# Altium schematic sheet DE-140824_01.SchDoc(DE-140824_01)
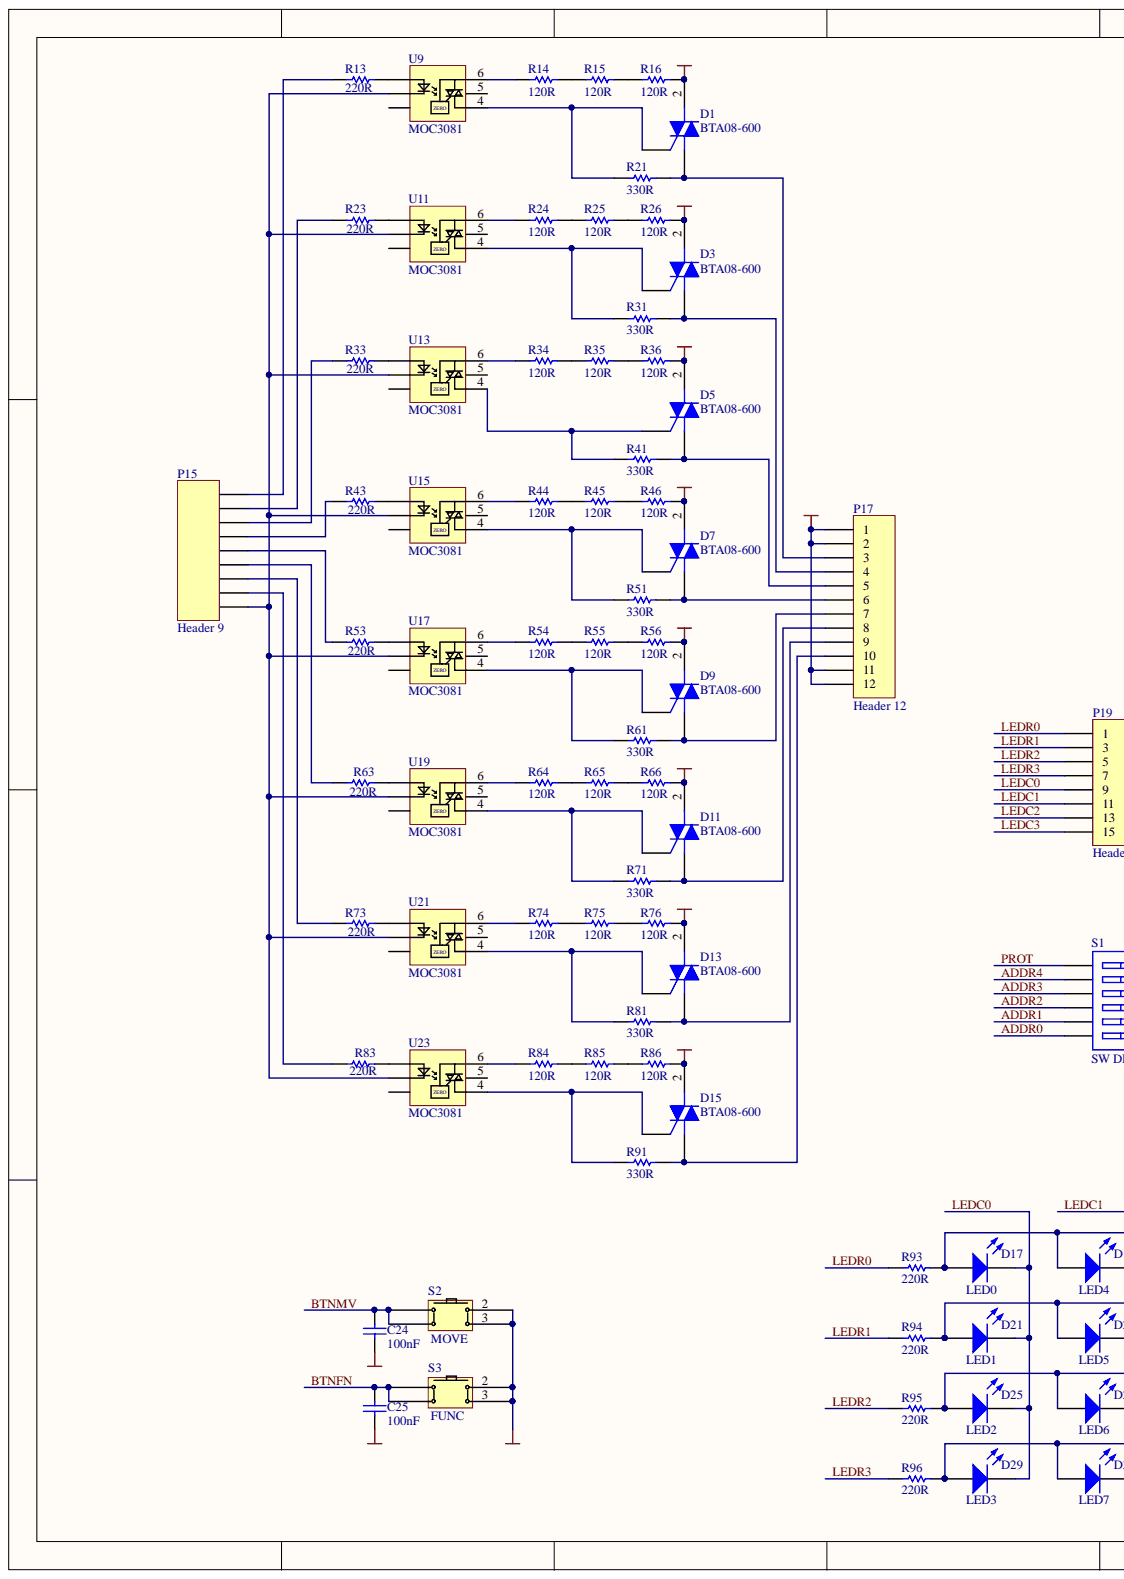
[diagram p0: DE-140824_01.SchDoc, left]
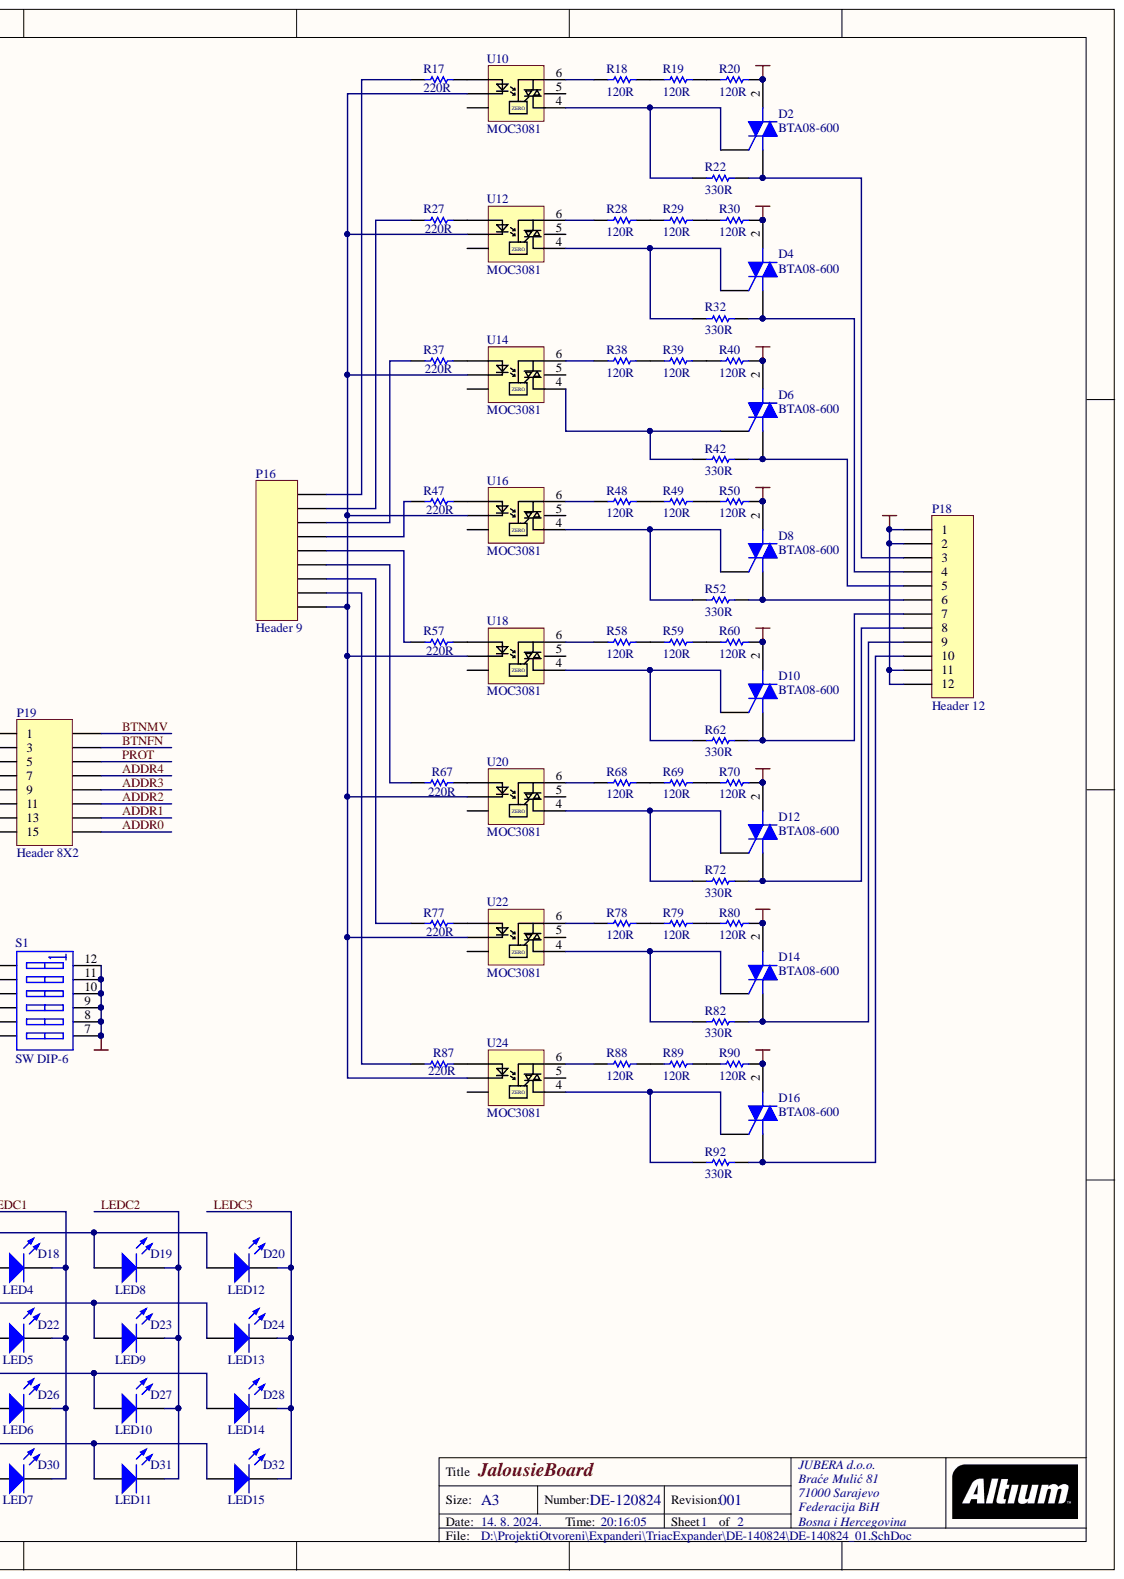
[diagram p1: DE-140824_01.SchDoc, right]

## Parts
C24  value 100nF  [0603]  (hidden comment: C0603)
C25  value 100nF  [0603]  (hidden comment: C0603)
D1  BTA08-600  [ONSC-TO-220-3-221A-07]
D2  BTA08-600  [ONSC-TO-220-3-221A-07]
D3  BTA08-600  [ONSC-TO-220-3-221A-07]
D4  BTA08-600  [ONSC-TO-220-3-221A-07]
D5  BTA08-600  [ONSC-TO-220-3-221A-07]
D6  BTA08-600  [ONSC-TO-220-3-221A-07]
D7  BTA08-600  [ONSC-TO-220-3-221A-07]
D8  BTA08-600  [ONSC-TO-220-3-221A-07]
D9  BTA08-600  [ONSC-TO-220-3-221A-07]
D10  BTA08-600  [ONSC-TO-220-3-221A-07]
D11  BTA08-600  [ONSC-TO-220-3-221A-07]
D12  BTA08-600  [ONSC-TO-220-3-221A-07]
D13  BTA08-600  [ONSC-TO-220-3-221A-07]
D14  BTA08-600  [ONSC-TO-220-3-221A-07]
D15  BTA08-600  [ONSC-TO-220-3-221A-07]
D16  BTA08-600  [ONSC-TO-220-3-221A-07]
D17  LED0  [1.6X0.8X0.8]
D18  LED4  [1.6X0.8X0.8]
D19  LED8  [1.6X0.8X0.8]
D20  LED12  [1.6X0.8X0.8]
D21  LED1  [1.6X0.8X0.8]
D22  LED5  [1.6X0.8X0.8]
D23  LED9  [1.6X0.8X0.8]
D24  LED13  [1.6X0.8X0.8]
D25  LED2  [1.6X0.8X0.8]
D26  LED6  [1.6X0.8X0.8]
D27  LED10  [1.6X0.8X0.8]
D28  LED14  [1.6X0.8X0.8]
D29  LED3  [1.6X0.8X0.8]
D30  LED7  [1.6X0.8X0.8]
D31  LED11  [1.6X0.8X0.8]
D32  LED15  [1.6X0.8X0.8]
P15  Header 9  [HDR1X9]
P16  Header 9  [HDR1X9]
P17  Header 12  [HDR1X12]
P18  Header 12  [HDR1X12]
P19  Header 8X2  [HDR2X8]
R13  220R  [ERA-0603]
R14  120R  [ERA-0805]
R15  120R  [ERA-0805]
R16  120R  [ERA-0805]
R17  220R  [ERA-0603]
R18  120R  [ERA-0805]
R19  120R  [ERA-0805]
R20  120R  [ERA-0805]
R21  330R  [ERA-0805]
R22  330R  [ERA-0805]
R23  220R  [ERA-0603]
R24  120R  [ERA-0805]
R25  120R  [ERA-0805]
R26  120R  [ERA-0805]
R27  220R  [ERA-0603]
R28  120R  [ERA-0805]
R29  120R  [ERA-0805]
R30  120R  [ERA-0805]
R31  330R  [ERA-0805]
R32  330R  [ERA-0805]
R33  220R  [ERA-0603]
R34  120R  [ERA-0805]
R35  120R  [ERA-0805]
R36  120R  [ERA-0805]
R37  220R  [ERA-0603]
R38  120R  [ERA-0805]
R39  120R  [ERA-0805]
R40  120R  [ERA-0805]
R41  330R  [ERA-0805]
R42  330R  [ERA-0805]
R43  220R  [ERA-0603]
R44  120R  [ERA-0805]
R45  120R  [ERA-0805]
R46  120R  [ERA-0805]
R47  220R  [ERA-0603]
R48  120R  [ERA-0805]
R49  120R  [ERA-0805]
R50  120R  [ERA-0805]
R51  330R  [ERA-0805]
R52  330R  [ERA-0805]
R53  220R  [ERA-0603]
R54  120R  [ERA-0805]
R55  120R  [ERA-0805]
R56  120R  [ERA-0805]
R57  220R  [ERA-0603]
R58  120R  [ERA-0805]
R59  120R  [ERA-0805]
R60  120R  [ERA-0805]
R61  330R  [ERA-0805]
R62  330R  [ERA-0805]
R63  220R  [ERA-0603]
R64  120R  [ERA-0805]
R65  120R  [ERA-0805]
R66  120R  [ERA-0805]
R67  220R  [ERA-0603]
R68  120R  [ERA-0805]
R69  120R  [ERA-0805]
R70  120R  [ERA-0805]
R71  330R  [ERA-0805]
R72  330R  [ERA-0805]
R73  220R  [ERA-0603]
R74  120R  [ERA-0805]
R75  120R  [ERA-0805]
R76  120R  [ERA-0805]
R77  220R  [ERA-0603]
R78  120R  [ERA-0805]
R79  120R  [ERA-0805]
R80  120R  [ERA-0805]
R81  330R  [ERA-0805]
R82  330R  [ERA-0805]
R83  220R  [ERA-0603]
R84  120R  [ERA-0805]
R85  120R  [ERA-0805]
R86  120R  [ERA-0805]
R87  220R  [ERA-0603]
R88  120R  [ERA-0805]
R89  120R  [ERA-0805]
R90  120R  [ERA-0805]
R91  330R  [ERA-0805]
R92  330R  [ERA-0805]
R93  220R  [ERA-0603]
R94  220R  [ERA-0603]
R95  220R  [ERA-0603]
R96  220R  [ERA-0603]
S1  SW DIP-6  [DIP-12/SW]
S2  MOVE  [TACTILE_SWITCH]
S3  FUNC  [TACTILE_SWITCH]
U9  MOC3081  [DIP6]
U10  MOC3081  [DIP6]
U11  MOC3081  [DIP6]
U12  MOC3081  [DIP6]
U13  MOC3081  [DIP6]
U14  MOC3081  [DIP6]
U15  MOC3081  [DIP6]
U16  MOC3081  [DIP6]
U17  MOC3081  [DIP6]
U18  MOC3081  [DIP6]
U19  MOC3081  [DIP6]
U20  MOC3081  [DIP6]
U21  MOC3081  [DIP6]
U22  MOC3081  [DIP6]
U23  MOC3081  [DIP6]
U24  MOC3081  [DIP6]

## Nets
F1: D1.2 D3.2 D5.2 D7.2 D9.2 D11.2 D13.2 D15.2 P17.1 P17.2 P17.11 P17.12 R16.2 R26.2 R36.2 R46.2 R56.2 R66.2 R76.2 R86.2
F2: D2.2 D4.2 D6.2 D8.2 D10.2 D12.2 D14.2 D16.2 P18.1 P18.2 P18.11 P18.12 R20.2 R30.2 R40.2 R50.2 R60.2 R70.2 R80.2 R90.2
GND1: C24.2 C25.2 S1.7 S1.8 S1.9 S1.10 S1.11 S1.12 S2.2 S2.3 S3.2 S3.3
ADDR0: P19.16 S1.6
ADDR1: P19.14 S1.5
ADDR2: P19.12 S1.4
ADDR3: P19.10 S1.3
ADDR4: P19.8 S1.2
BTNFN: C25.1 P19.4 S3.1 S3.4
BTNMV: C24.1 P19.2 S2.1 S2.4
LEDC0: D17.2 D21.2 D25.2 D29.2 P19.9
LEDC1: D18.2 D22.2 D26.2 D30.2 P19.11
LEDC2: D19.2 D23.2 D27.2 D31.2 P19.13
LEDC3: D20.2 D24.2 D28.2 D32.2 P19.15
LEDR0: P19.1 R93.1
LEDR1: P19.3 R94.1
LEDR2: P19.5 R95.1
LEDR3: P19.7 R96.1
PROT: P19.6 S1.1
NetD1_1: D1.1 P17.3 R21.2
NetD1_3: D1.3 R21.1 U9.4
NetD2_1: D2.1 P18.3 R22.2
NetD2_3: D2.3 R22.1 U10.4
NetD3_1: D3.1 P17.4 R31.2
NetD3_3: D3.3 R31.1 U11.4
NetD4_1: D4.1 P18.4 R32.2
NetD4_3: D4.3 R32.1 U12.4
NetD5_1: D5.1 P17.5 R41.2
NetD5_3: D5.3 R41.1 U13.4
NetD6_1: D6.1 P18.5 R42.2
NetD6_3: D6.3 R42.1 U14.4
NetD7_1: D7.1 P17.6 R51.2
NetD7_3: D7.3 R51.1 U15.4
NetD8_1: D8.1 P18.6 R52.2
NetD8_3: D8.3 R52.1 U16.4
NetD9_1: D9.1 P17.7 R61.2
NetD9_3: D9.3 R61.1 U17.4
NetD10_1: D10.1 P18.7 R62.2
NetD10_3: D10.3 R62.1 U18.4
NetD11_1: D11.1 P17.8 R71.2
NetD11_3: D11.3 R71.1 U19.4
NetD12_1: D12.1 P18.8 R72.2
NetD12_3: D12.3 R72.1 U20.4
NetD13_1: D13.1 P17.9 R81.2
NetD13_3: D13.3 R81.1 U21.4
NetD14_1: D14.1 P18.9 R82.2
NetD14_3: D14.3 R82.1 U22.4
NetD15_1: D15.1 P17.10 R91.2
NetD15_3: D15.3 R91.1 U23.4
NetD16_1: D16.1 P18.10 R92.2
NetD16_3: D16.3 R92.1 U24.4
NetD17_1: D17.1 D18.1 D19.1 D20.1 R93.2
NetD21_1: D21.1 D22.1 D23.1 D24.1 R94.2
NetD25_1: D25.1 D26.1 D27.1 D28.1 R95.2
NetD29_1: D29.1 D30.1 D31.1 D32.1 R96.2
NetP15_1: P15.1 R13.1
NetP15_2: P15.2 R23.1
NetP15_3: P15.3 R33.1
NetP15_4: P15.4 R43.1
NetP15_5: P15.5 R53.1
NetP15_6: P15.6 R63.1
NetP15_7: P15.7 R73.1
NetP15_8: P15.8 R83.1
NetP15_9: P15.9 U9.2 U11.2 U13.2 U15.2 U17.2 U19.2 U21.2 U23.2
NetP16_1: P16.1 R17.1
NetP16_2: P16.2 R27.1
NetP16_3: P16.3 R37.1
NetP16_4: P16.4 R47.1
NetP16_5: P16.5 R57.1
NetP16_6: P16.6 R67.1
NetP16_7: P16.7 R77.1
NetP16_8: P16.8 R87.1
NetP16_9: P16.9 U10.2 U12.2 U14.2 U16.2 U18.2 U20.2 U22.2 U24.2
NetR13_2: R13.2 U9.1
NetR14_1: R14.1 U9.6
NetR14_2: R14.2 R15.1
NetR15_2: R15.2 R16.1
NetR17_2: R17.2 U10.1
NetR18_1: R18.1 U10.6
NetR18_2: R18.2 R19.1
NetR19_2: R19.2 R20.1
NetR23_2: R23.2 U11.1
NetR24_1: R24.1 U11.6
NetR24_2: R24.2 R25.1
NetR25_2: R25.2 R26.1
NetR27_2: R27.2 U12.1
NetR28_1: R28.1 U12.6
NetR28_2: R28.2 R29.1
NetR29_2: R29.2 R30.1
NetR33_2: R33.2 U13.1
NetR34_1: R34.1 U13.6
NetR34_2: R34.2 R35.1
NetR35_2: R35.2 R36.1
NetR37_2: R37.2 U14.1
NetR38_1: R38.1 U14.6
NetR38_2: R38.2 R39.1
NetR39_2: R39.2 R40.1
NetR43_2: R43.2 U15.1
NetR44_1: R44.1 U15.6
NetR44_2: R44.2 R45.1
NetR45_2: R45.2 R46.1
NetR47_2: R47.2 U16.1
NetR48_1: R48.1 U16.6
NetR48_2: R48.2 R49.1
NetR49_2: R49.2 R50.1
NetR53_2: R53.2 U17.1
NetR54_1: R54.1 U17.6
NetR54_2: R54.2 R55.1
NetR55_2: R55.2 R56.1
NetR57_2: R57.2 U18.1
NetR58_1: R58.1 U18.6
NetR58_2: R58.2 R59.1
NetR59_2: R59.2 R60.1
NetR63_2: R63.2 U19.1
NetR64_1: R64.1 U19.6
NetR64_2: R64.2 R65.1
NetR65_2: R65.2 R66.1
NetR67_2: R67.2 U20.1
NetR68_1: R68.1 U20.6
NetR68_2: R68.2 R69.1
NetR69_2: R69.2 R70.1
NetR73_2: R73.2 U21.1
NetR74_1: R74.1 U21.6
NetR74_2: R74.2 R75.1
NetR75_2: R75.2 R76.1
NetR77_2: R77.2 U22.1
NetR78_1: R78.1 U22.6
NetR78_2: R78.2 R79.1
NetR79_2: R79.2 R80.1
NetR83_2: R83.2 U23.1
NetR84_1: R84.1 U23.6
NetR84_2: R84.2 R85.1
NetR85_2: R85.2 R86.1
NetR87_2: R87.2 U24.1
NetR88_1: R88.1 U24.6
NetR88_2: R88.2 R89.1
NetR89_2: R89.2 R90.1

Not connected: U9 3 5; U10 3 5; U11 3 5; U12 3 5; U13 3 5; U14 3 5; U15 3 5; U16 3 5; U17 3 5; U18 3 5; U19 3 5; U20 3 5; U21 3 5; U22 3 5; U23 3 5; U24 3 5

## Library data of the parts
C24 C25 = C0603: Ceramic Chip Capacitor - Standard; Note: Footprint corresponds to dimensions for bulk cassette packaging. This case size has tightened tolerances.; Simulation: CAP; Signal Integrity: C0603; Published: 17-Jul-2002; PackageReference: 0603; PackageDocument: Mar-2001; Library Name: DE-120824.SchLib; LatestRevisionNote: Silkscreen size reduced.; LatestRevisionDate: 19-Mar-2004; DatasheetDocument: Mar-2001; ComponentLink2URL: http://www.kemet.com/kemet/web/homepage/kechome.nsf/vapubfilesname/F3102TA.pdf/$file/F3102TA.pdf; ComponentLink2Description: Datasheet; ComponentLink1URL: http://www.kemet.com/; ComponentLink1Description: Manufacturer Link; Component Kind: Standard; Code_JEITA: 0603; Code_IPC: 1608 [0603]; Publisher: Altium Limited
D1-D16 = BTA08-600BW3G: Triacs, Silicon Bidirectional Thyristor, 3-Pin TO-220, Pb-Free, Tube; Manufacturer: On Semiconductor; ITSM Max (A): 90; IT(RMS) Max (A): 8; IGT Max (mA): 50; Supplier Part Number 3: 863-BTA08-600BW3G; Supplier Part Number 2: 13P2072; Supplier Part Number 1: BTA08-600BW3G-ND; Supplier 3: Mouser; Supplier 2: Newark; Supplier 1: Digi-Key; RoHS: Pb-Free; PartNumber: BTA08-600BW3G; Packing: Tube; PackageVersion: Rev. O, 07/2008; PackageReference: TO-220-3-221A-07; PackageDescription: 3-Pin Flange Mount, Vertical Straight Lead, Body 9.97 x 4.445 mm, Pitch 2.54 mm; Mounting Technology: Through Hole; Library Name: DE-120824.SchLib; DatasheetVersion: Rev. 1; ComponentLink3URL: http://www.onsemi.com/pub_link/Collateral/221A-07.PDF; ComponentLink3Description: Package Specification; ComponentLink2URL: http://www.onsemi.com/pub_link/Collateral/BTA08-600BW3-D.PDF; ComponentLink2Description: Datasheet; ComponentLink1URL: http://www.onsemi.com/; ComponentLink1Description: Manufacturer URL; Component Kind: Standard
D17-D32 = HSMB-C190: Blue Surface Mount Chip LED, Blue; PCB_Layout: Complies with manufacturer's recommendation.; LuminousIntensity: 1.6mcd (Minimum).; Simulation: Agl_HSMBC110; Signal Integrity: LED; Published: 11-Dec-2002; PackageVersion: Mar-2002; PackageReference: 1.6X0.8X0.8; PackageDescription: SM LED; 2 Flat Leads; Library Name: DE-120824.SchLib; LED_Colour: Blue; DatasheetVersion: 26-Feb-2002; ComponentLink2URL: http://cp.literature.agilent.com/litweb/pdf/5988-5211EN.pdf; ComponentLink2Description: Datasheet; ComponentLink1URL: http://www.avagotech.com/; ComponentLink1Description: Manufacturer Link; Component Kind: Standard; Publisher: Altium Limited
P15 P16 = Header 9: Header, 9-Pin; Signal Integrity: Connector; Library Name: Miscellaneous Connectors.IntLib; LatestRevisionNote: Re-released for DXP Platform.; LatestRevisionDate: 17-Jul-2002; Component Kind: Standard; Publisher: Altium Limited
P17 P18 = Header 12: Header, 12-Pin; Signal Integrity: Connector; Library Name: Miscellaneous Connectors.IntLib; LatestRevisionNote: Re-released for DXP Platform.; LatestRevisionDate: 17-Jul-2002; Component Kind: Standard; Publisher: Altium Limited
P19 = Header 8X2: Header, 8-Pin, Dual row; Signal Integrity: Connector; Library Name: Miscellaneous Connectors.IntLib; LatestRevisionNote: Re-released for DXP Platform.; LatestRevisionDate: 17-Jul-2002; Component Kind: Standard; Publisher: Altium Limited
R13 R17 R23 R27 R33 R37 R43 R47 R53 R57 R63 R67 R73 R77 R83 R87 = ERA3Y: Rectangular Thick Film Chip Resistor, 10 Ohm to 330k Ohm Range, 0.1% and 0.5% Tolerance, 0603 Size, 0.063 W; Note: If flow soldering, adjust pad width of PCB Footprint to 0.7 - 0.8 times the width of the resistor.; Simulation: RESISTOR; Signal Integrity: Res; Published: 17-Dec-2003; PackageReference: ERA-0603; PackageDocument: Mar-2003; Library Name: DE-120824.SchLib; LatestRevisionNote: Silkscreen size reduced.; LatestRevisionDate: 19-Mar-2004; ComponentLink2URL: http://www.panasonic.com/industrial/components/pdf/AOA0000CE8.pdf; ComponentLink2Description: Datasheet; ComponentLink1URL: http://www.panasonic.com/industrial/components/resistive_products.htm; ComponentLink1Description: Manufacturer Link; Component Kind: Standard; Code_IPC: 1608 [0603]; Publisher: Altium Limited
R14-R16 R18-R22 R24-R26 R28-R32 R34-R36 R38-R42 R44-R46 R48-R52 R54-R56 R58-R62 R64-R66 R68-R72 R74-R76 R78-R82 R84-R86 R88-R96 = ERAS: Metal Film Thermosensitive Chip Resistor, 0.56 Ohm to 10k Ohm Range, 5% Tolerance, 0805 Size, 0.1 W; Note: If flow soldering, adjust pad width of PCB Footprint to 0.7 - 0.8 times the width of the resistor.; Simulation: RESISTOR; Signal Integrity: Res; Published: 17-Dec-2003; PackageReference: ERA-0805; PackageDocument: Mar-2003; Library Name: DE-120824.SchLib; LatestRevisionNote: Silkscreen size reduced.; LatestRevisionDate: 19-Mar-2004; ComponentLink2URL: http://www.panasonic.com/industrial/components/pdf/AOF0000CE1.pdf; ComponentLink2Description: Datasheet; ComponentLink1URL: http://www.panasonic.com/industrial/components/resistive_products.htm; ComponentLink1Description: Manufacturer Link; Component Kind: Standard; Code_IPC: 2012 [0805]; Publisher: Altium Limited
S1 = SW DIP-6: DIP Switch, 6 Position, SPST; Simulation: dpsw6; Published: 24-Mar-1999; PackageReference: DIP-12/SW; PackageDescription: DIP Switch; 12 Leads; Library Name: Miscellaneous Devices.IntLib; LatestRevisionNote: Re-released for DXP Platform.; LatestRevisionDate: 17-Jul-2002; Component Kind: Standard; Publisher: Altium Limited
S2 S3 = TACKTILE_SWITCH: Library Name: DE-120824.SchLib; Component Kind: Standard
U9-U24 = MOC3081: Zero-Cross Optoisolator Triac Driver; Library Name: DE-120824.SchLib; Component Kind: Standard
